# Altium schematic sheet Microcontroller.SchDoc(Microcontroller)
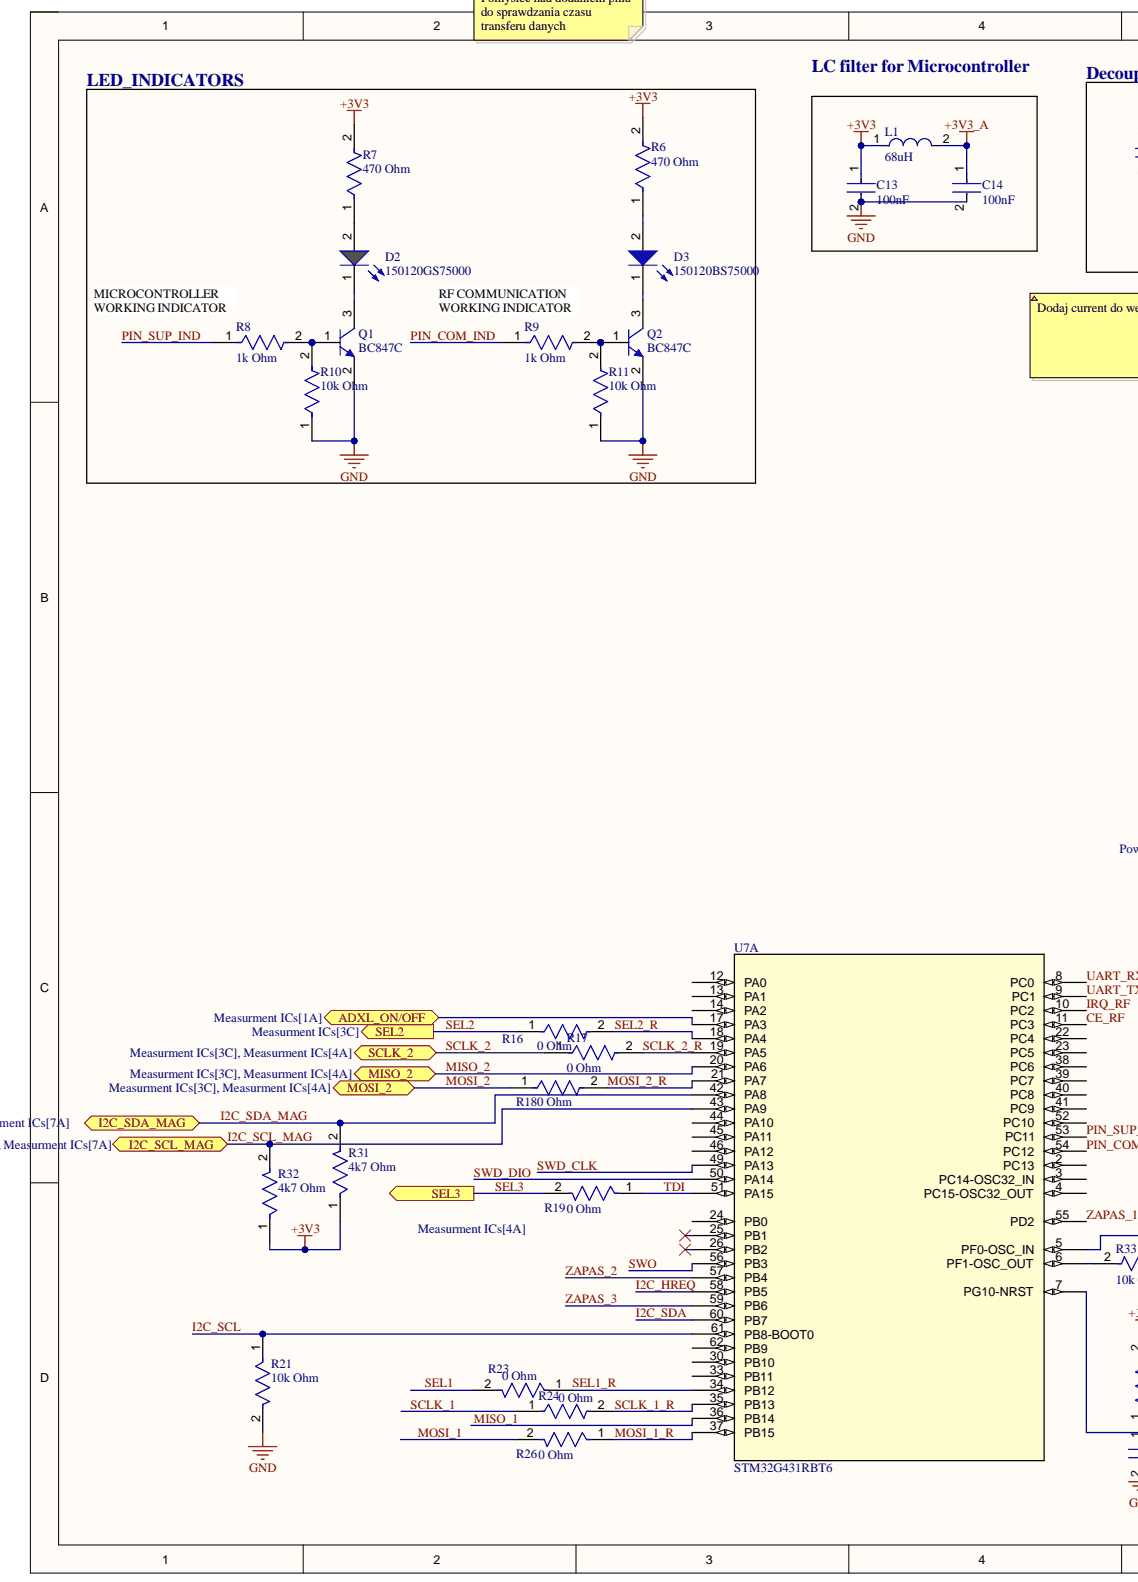
[diagram p0: Microcontroller.SchDoc, left]
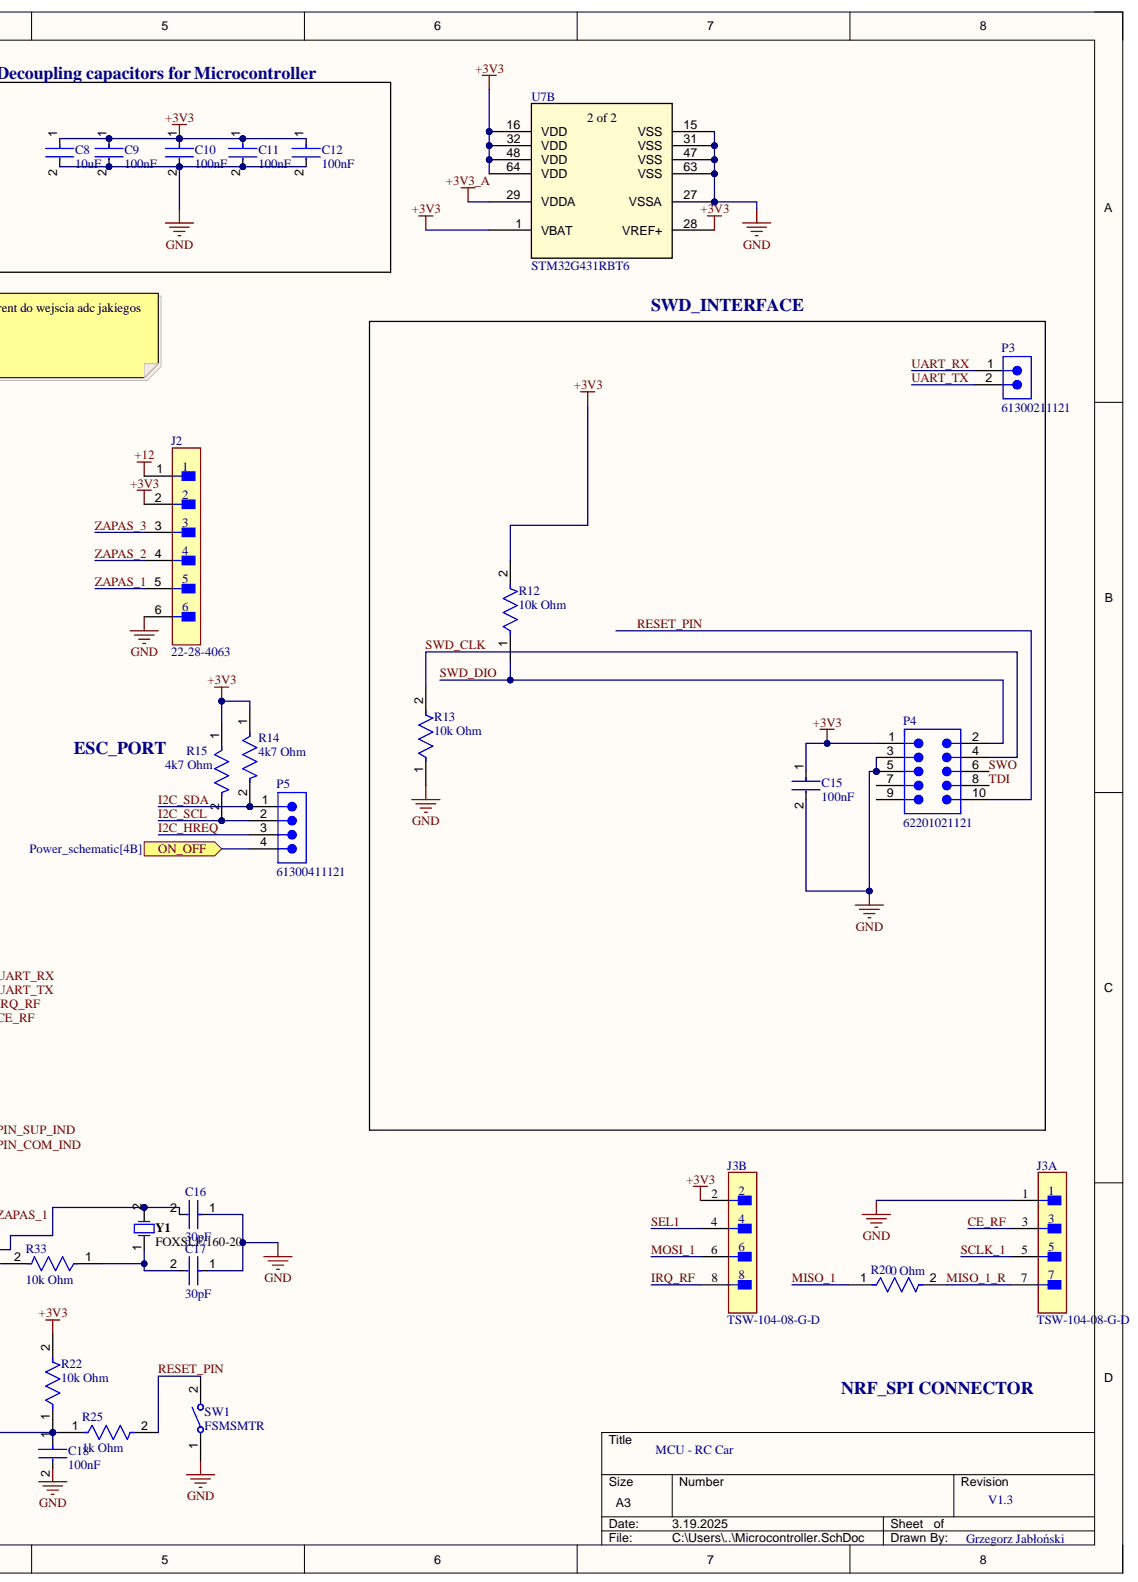
[diagram p1: Microcontroller.SchDoc, right]

## Parts
C8  10uF  [FP-CC0805-0_85-MFG]
C9  100nF  [FP-CC0805-0_85-MFG]
C10  100nF  [FP-CC0805-0_85-MFG]
C11  100nF  [FP-CC0805-0_85-MFG]
C12  100nF  [FP-CC0805-0_85-MFG]
C13  100nF  [FP-CC0805-0_85-MFG]
C14  100nF  [FP-CC0805-0_85-MFG]
C15  100nF  [FP-CC0805-0_85-MFG]
C16  30pF  [FP-CC0805-0_85-MFG]
C17  30pF  [FP-CC0805-0_85-MFG]
C18  100nF  [FP-CC0805-0_85-MFG]
D2  150120GS75000  [WL-SMCW_1206_150120xx75000]
D3  150120BS75000  [WL-SMCW_1206_150120xx75000]
J2  22-28-4063  [FP-22-28-4063-MFG]
J3  TSW-104-08-G-D  [FP-TSW-104-08-G-D-MFG]  (parts A,B)
L1  68uH  [FP-CB2518-MFG]
P3  61300211121  [61300211121]
P4  62201021121  [62201021121]
P5  61300411121  [61300411121]
Q1  BC847C  [INF-SOT23_L]
Q2  BC847C  [INF-SOT23_L]
R6  470 Ohm  [FP-RMCF0805-MFG]
R7  470 Ohm  [FP-RMCF0805-MFG]
R8  1k Ohm  [FP-RMCF0805-MFG]
R9  1k Ohm  [FP-RMCF0805-MFG]
R10  10k Ohm  [FP-RMCF0805-MFG]
R11  10k Ohm  [FP-RMCF0805-MFG]
R12  10k Ohm  [FP-RMCF0805-MFG]
R13  10k Ohm  [FP-RMCF0805-MFG]
R14  4k7 Ohm  [FP-RMCF0805-MFG]
R15  4k7 Ohm  [FP-RMCF0805-MFG]
R16  0 Ohm  [FP-RMCF0805-MFG]
R17  0 Ohm  [FP-RMCF0805-MFG]
R18  0 Ohm  [FP-RMCF0805-MFG]
R19  0 Ohm  [FP-RMCF0805-MFG]
R20  0 Ohm  [FP-RMCF0805-MFG]
R21  10k Ohm  [FP-RMCF0805-MFG]
R22  10k Ohm  [FP-RMCF0805-MFG]
R23  0 Ohm  [FP-RMCF0805-MFG]
R24  0 Ohm  [FP-RMCF0805-MFG]
R25  1k Ohm  [FP-RMCF0805-MFG]
R26  0 Ohm  [FP-RMCF0805-MFG]
R31  4k7 Ohm  [FP-RMCF0805-MFG]
R32  4k7 Ohm  [FP-RMCF0805-MFG]
R33  10k Ohm  [FP-RMCF0805-MFG]
SW1  FSMSMTR  [TECO-FSMSMTR_V]
U7  STM32G431RBT6  [LQFP64-10x10mm]  (parts A,B)
Y1  FOXSLF/160-20  [FOX-FOXSLF160-20]

## Ports
ADXL_ON/OFF, I2C_SCL_MAG, I2C_SDA_MAG, MISO_2, MOSI_2, ON_OFF, SCLK_2, SEL2, SEL3

## Nets
+3V3: C8.1 C9.1 C10.1 C11.1 C12.1 C13.1 C15.1 J2.2 J3.2 L1.1 P4.1 R6.2 R7.2 R12.2 R14.1 R15.1 R22.2 R31.1 R32.1 U7.1(VBAT) U7.16(VDD) U7.28(VREF+) U7.32(VDD) U7.48(VDD) U7.64(VDD)
+3V3_A: C14.1 L1.2 U7.29(VDDA)
+12: J2.1
GND: C8.2 C9.2 C10.2 C11.2 C12.2 C13.2 C14.2 C15.2 C16.1 C17.1 C18.2 J2.6 J3.1 P4.3 P4.5 Q1.2 Q2.2 R10.1 R11.1 R13.1 R21.2 SW1.1 U7.15(VSS) U7.27(VSSA) U7.31(VSS) U7.47(VSS) U7.63(VSS)
ADXL_ON/OFF: U7.17(PA3) ; port ADXL_ON/OFF
CE_RF: J3.3 U7.11(PC3)
I2C_HREQ: P5.3 U7.58(PB5)
I2C_SCL: P5.2 R15.2 R21.1 U7.61(PB8-BOOT0)
I2C_SCL_MAG: R32.2 U7.43(PA9) ; port I2C_SCL_MAG
I2C_SDA: P5.1 R14.2 U7.60(PB7)
I2C_SDA_MAG: R31.2 U7.42(PA8) ; port I2C_SDA_MAG
IRQ_RF: J3.8 U7.10(PC2)
MISO_1: R20.1 U7.36(PB14)
MISO_1_R: J3.7 R20.2
MISO_2: U7.20(PA6) ; port MISO_2
MOSI_1: J3.6 R26.2
MOSI_1_R: R26.1 U7.37(PB15)
MOSI_2: R18.1 ; port MOSI_2
MOSI_2_R: R18.2 U7.21(PA7)
ON_OFF: P5.4 ; port ON_OFF
PIN_COM_IND: R9.1 U7.54(PC12)
PIN_SUP_IND: R8.1 U7.53(PC11)
RESET_PIN: P4.10 R25.2 SW1.2
SCLK_1: J3.5 R24.1
SCLK_1_R: R24.2 U7.35(PB13)
SCLK_2: R17.1 ; port SCLK_2
SCLK_2_R: R17.2 U7.19(PA5)
SEL1: J3.4 R23.2
SEL1_R: R23.1 U7.34(PB12)
SEL2: R16.1 ; port SEL2
SEL2_R: R16.2 U7.18(PA4)
SEL3: R19.2 ; port SEL3
SWD_CLK: P4.4 R13.2 U7.49(PA13)
SWD_DIO: P4.2 R12.1 U7.50(PA14)
SWO: P4.6 U7.56(PB3)
TDI: P4.8 R19.1 U7.51(PA15)
UART_RX: P3.1 U7.8(PC0)
UART_TX: P3.2 U7.9(PC1)
ZAPAS_1: J2.5 U7.55(PD2)
ZAPAS_2: J2.4 U7.57(PB4)
ZAPAS_3: J2.3 U7.59(PB6)
NetC16_2: C16.2 U7.5(PF0-OSC_IN) Y1.2
NetC17_2: C17.2 R33.1 Y1.1
NetC18_1: C18.1 R22.1 R25.1 U7.7(PG10-NRST)
NetD2_1: D2.1 Q1.3
NetD2_2: D2.2 R7.1
NetD3_1: D3.1 Q2.3
NetD3_2: D3.2 R6.1
NetQ1_1: Q1.1 R8.2 R10.2
NetQ2_1: Q2.1 R9.2 R11.2
NetR33_2: R33.2 U7.6(PF1-OSC_OUT)

Not connected: P4 7 9; U7 2(PC13) 3(PC14-OSC32_IN) 4(PC15-OSC32_OUT) 12(PA0) 13(PA1) 14(PA2) 22(PC4) 23(PC5) 24(PB0) 25(PB1) 26(PB2) 30(PB10) 33(PB11) 38(PC6) 39(PC7) 40(PC8) 41(PC9) 44(PA10) 45(PA11) 46(PA12) 52(PC10) 62(PB9)

## Library data of the parts
C8 C16 C17 = CMP-2100-03606-3: CAP CER 0.1UF 50V X7R 0805; Manufacturer: Yageo; Manufacturer Part Number: CC0805KRX7R9BB104; Capacitance: 100 nF; Material: Ceramic; Tolerance: 0.1; Value: 100 nF; Automotive: No; Category: Cap; Component Kind: Standard; ComponentLink2Description: Datasheet; ComponentLink2URL: http://www.yageo.com/documents/recent/UPY-GPHC_Y5V_6.3V-to-50V_8.pdf; Device Class L1: Passive Components; Device Class L2: Capacitors; Device Class L3: Ceramic Capacitors; Digikey Description: CAP CER 0.1UF 50V X7R 0805; Footprint Url: http://www.yageo.com/exep/pages/download/literatures/UPY-C_GEN_24.pdf; Height: 0.95mm; Ipc Land Pattern Name: CAPC200125X85; Lead Free: Yes; Library Name: Altium Content Vault; Mouser Description: Multilayer Ceramic Capacitors MLCC - SMD/SMT 100nF 50V X7R 10%; Octopart: https://octopart.com/cc0805krx7r9bb104-yageo-192993; Package: CC0805-0.85; RoHS: TRUE; Temperature: -30°C to +85°C; Temperature Characteristic: X7R; Temperature Range High: +85°C; Temperature Range Low: -30°C; Voltage Rating: 50 V
C9-C15 C18 = CMP-2100-03606-3: CAP CER 0.1UF 50V X7R 0805; Manufacturer: Yageo; Manufacturer Part Number: CC0805KRX7R9BB104; Material: Ceramic; Tolerance: 0.1; Automotive: No; Capacitance: 100 nF; Category: Cap; Component Kind: Standard; ComponentLink2Description: Datasheet; ComponentLink2URL: http://www.yageo.com/documents/recent/UPY-GPHC_Y5V_6.3V-to-50V_8.pdf; Device Class L1: Passive Components; Device Class L2: Capacitors; Device Class L3: Ceramic Capacitors; Digikey Description: CAP CER 0.1UF 50V X7R 0805; Footprint Url: http://www.yageo.com/exep/pages/download/literatures/UPY-C_GEN_24.pdf; Height: 0.95mm; Ipc Land Pattern Name: CAPC200125X85; Lead Free: Yes; Library Name: Altium Content Vault; Mouser Description: Multilayer Ceramic Capacitors MLCC - SMD/SMT 100nF 50V X7R 10%; Octopart: https://octopart.com/cc0805krx7r9bb104-yageo-192993; Package: CC0805-0.85; RoHS: TRUE; Temperature: -30°C to +85°C; Temperature Characteristic: X7R; Temperature Range High: +85°C; Temperature Range Low: -30°C; Value: 100 nF; Voltage Rating: 50 V
D2 = CMP-1426-00014-4: WL-SMCW SMT Mono-Color Chip LED Waterclear; Color: Green; Dominant Wavelength: 525nm; Forward Current: 100mA; Forward Voltage: 3.2V; Lens Style: Clear, Rectangular; Luminous Intensity: 450mcd; Max Junction Temperature (Tj): 95°C; Max Reverse Voltage (DC): 5V; Peak Wavelength: 515nm; Power Dissipation: 105mW; Test Current: 20mA; Viewing Angle: 140°; Case Code (Imperial): 1206; Case Code (Metric): 3216; Case/Package: 1206; Component Kind: Standard; Height: 680µm; Length: 3.2mm; Library Name: Altium Content Vault; Max Operating Temperature: 85°C; Min Operating Temperature: -40°C; Mount: Surface Mount; Packaging: Tape and Reel; REACH SVHC: Unknown; Reverse Voltage: 5V; RoHS: Compliant; Wavelength: 525nm; Width: 1.6mm
D3 = CMP-1426-00015-3: WL-SMCW SMT Mono-Color Chip LED Waterclear; Manufacturer Package Identifier: LED_1206; Color: Blue; Dominant Wavelength: 470nm; Forward Current: 100mA; Forward Voltage: 3.2V; Lens Style: Clear, Rectangular; Luminous Intensity: 145mcd; Max Reverse Voltage (DC): 5V; Peak Wavelength: 465nm; Test Current: 20mA; Viewing Angle: 140°; Case Code (Imperial): 1206; Case Code (Metric): 3216; Case/Package: 1206; Component Kind: Standard; Height: 680µm; Length: 3.2mm; Library Name: Altium Content Vault; Max Operating Temperature: 85°C; Min Operating Temperature: -40°C; Mount: Surface Mount; Packaging: Tape and Reel; REACH SVHC: Unknown; RoHS: Compliant; Wavelength: 470nm; Width: 1.6mm
J2 = CMP-04776-000885-1: CONN HEADER VERT 6POS 2.54MM; Connector Type: Male Pin; Contact Resistance: 20mR; Current Rating: 4A; Gender: Male; Glow Wire Compliant: Non-compliant; Max Voltage Rating (AC): 250V; Max Voltage Rating (DC): 250.25kV; Number of Circuits: 6; Color: Black; Component Kind: Standard; Contact Material: Brass; Contact Mating Length: 0.24inch; Contact Plating: Gold; Contact Type: Male Pin; Depth: 2.49mm; Flammability Rating: UL94 V-0; Housing Color: Black; Housing Material: Thermoplastic; Lead Free: Lead Free; Library Name: Altium Content Vault; Max Operating Temperature: 75°C; Min Operating Temperature: 0°C; Mount: Through Hole; Number of Contacts: 6; Number of Positions: 6; Number of Rows: 1; Orientation: Straight; Package Quantity: 1; Packaging: Bulk; Pitch: 2.54mm; Radiation Hardening: No; RoHS Compliant: Yes; Series: KK® 42375; Termination: Solder; Voltage Rating: 250V; Voltage Rating (DC): 250V
J3 = CMP-00575-00272549-1: TSW-104-08-G-D TSW - Samtec 2.54mm Pin Header 13.46mm Overall Pin Length 5.08mm Tail (08) (mcv)(TO22); Manufacturer Lifecycle Status: PRODUCTION; NexarPartId: 272549; Connector Type: Male Pin; Gender: Male; Max Current Rating: 7.6A; Max Voltage Rating (AC): 550V; Number of Contacts: 8; Color: Black; Component Kind: Standard; Contact Material: Bronze; Contact Mating Length: 5.842mm; Contact Plating: Gold; Depth: 5.08mm; Housing Color: Black; Housing Material: Polyester; Lead Free: Lead Free; Lead Pitch: 2.54mm; Length: 10.16mm; Library Name: Altium Content Vault; Lifecycle Status: Production; Max Operating Temperature: 125°C; Min Operating Temperature: -55°C; Mount: Through Hole; Number of Positions: 8; Number of Rows: 2; Orientation: Straight; Packaging: Bulk; Pitch: 2.54mm; Radiation Hardening: No; REACH SVHC: No SVHC; RoHS: Compliant; Row Spacing: 2.54mm; Termination: Solder; Width: 5.08mm
L1 = CMP-14484-000100-1: SMD Inductors 68uH ±10% 0.31A 1.95Ω 1007; Core Material: Ferrite; Current Rating: 310mA; DC Resistance (DCR): 1.95R; Saturation Current: 70mA; Self Resonant Frequency: 9.5MHz; Shielding: Unshielded; Test Frequency: 2.52MHz; Case Code (Imperial): 1007; Case Code (Metric): 2518; Case/Package: 1007; Component Kind: Standard; Depth: 2mm; Halogen Free: Halogen Free; Height: 1.8mm; Height - Seated (Max): 0.079inch; Inductance: 68uH; Inductance Tolerance: 10%; Length: 0.098inch; Library Name: Altium Content Vault; Max DC Current: 310mA; Max Operating Temperature: 105°C; Min Operating Temperature: -40°C; Mount: Surface Mount; Package Quantity: 2000; Packaging: Tape and Reel; Radiation Hardening: No; Resistor Type: Wirewound; RoHS Compliant: Yes; Series: CB; Termination: SMD/SMT; Tolerance: 10%; Width: 0.071inch
P3 = CMP-1712-00002-6: NexarPartId: 20081794; Connector Type: Male Pin; Contact Resistance: 20mΩ; Current Rating: 3A; Gender: Male; Number of Contacts: 2; Operating Supply Voltage: 250V; Pitch: 2.54mm; Color: Black; Component Kind: Standard; Contact Gender: Male; Contact Material: Copper; Contact Mating Length: 5.9944mm; Contact Plating: Gold; Height: 8.94mm; Housing Material: PBT; Lead Free: Lead Free; Lead Pitch: 2.54mm; Library Name: Altium Content Vault; Max Operating Temperature: 125°C; Min Operating Temperature: -40°C; Mount: Through Hole; Number of Pins: 2; Number of Positions: 2; Number of Rows: 1; Orientation: Vertical; Packaging: Bulk; REACH SVHC: No SVHC; RoHS: Compliant; Termination: Through Hole; Voltage Rating: 250V
P4 = CMP-1502-00948-3: NexarPartId: 32855586; Connector Type: Male Pin; Contact Resistance: 20mΩ; Current Rating: 1A; Gender: Male; Number of Contacts: 10; Operating Supply Voltage: 100V; Pitch: 1.27mm; Color: Black; Component Kind: Standard; Contact Material: Copper; Contact Mating Length: 3.81mm; Contact Plating: Gold; Height: 5.65mm; Lead Pitch: 1.27mm; Library Name: Altium Content Vault; Max Operating Temperature: 105°C; Min Operating Temperature: -40°C; Mount: Through Hole; Number of Pins: 10; Number of Positions: 10; Number of Rows: 2; Orientation: Vertical; Packaging: Box; REACH SVHC: Unknown; RoHS: Compliant; Row Spacing: 1.27mm; Termination: Solder; Voltage Rating: 100V
P5 = CMP-1502-01064-3: NexarPartId: 32855522; Connector Type: Male Pin; Contact Resistance: 20mΩ; Current Rating: 3A; Gender: Male; Number of Contacts: 4; Operating Supply Voltage: 250V; Pitch: 2.54mm; Color: Black; Component Kind: Standard; Contact Material: Copper; Contact Mating Length: 5.9944mm; Contact Plating: Gold; Height: 8.89mm; Lead Free: Lead Free; Lead Pitch: 2.54mm; Library Name: Altium Content Vault; Max Operating Temperature: 105°C; Min Operating Temperature: -40°C; Mount: Through Hole; Number of Pins: 4; Number of Positions: 4; Number of Rows: 1; Orientation: Vertical; Packaging: Bulk; REACH SVHC: Unknown; RoHS: Compliant; Termination: Solder; Voltage Rating: 250V
Q1 Q2 = CMP-1177-00037-1: NPN Silicon AF Transistor, 45 V VCEO, 100 mA IC, SOT23, Reel, Green; Manufacturer: Infineon; fT (typ): 250Â MHz; Green: TRUE; IBM (max): 200Â mA; IC (max): 100Â mA; ICBO (max): 15Â nA; Ptot (max): 330Â mW; VCBO (max): 50Â V; VCEO (max): 45Â V; VEBO (max): 6Â V; Component Kind: Standard; ComponentLink1Description: Manufacturer URL; ComponentLink1URL: http://www.infineon.com/; ComponentLink2Description: Datasheet; ComponentLink2URL: http://www.infineon.com/dgdl/bc847series_bc848series_bc849series_bc850series.pdf?folderId=db3a304314dca389011541d30fa21656&fileId=db3a304314dca389011541d4630a1657; ComponentLink3Description: Package Specification; ComponentLink3URL: http://www.infineon.com/dgdl/SOT23-Package_Overview.pdf?folderId=db3a30431936bc4b0119532290053c66&fileId=db3a30431936bc4b0119533468123c85; ICM (max): 200Â mA; Library Name: Altium Content Vault; Mounting Technology: Surface Mount; PackageDescription: 3-Pin Small Outline Transistor Package, 1.3 x 2.9 mm Body, 0.95 mm Pitch; PackageReference: SOT23; PackageVersion: Apr-08; Packing: Reel; PartNumber: BC847C; Polarity: NPN
R6-R26 R31-R33 = CMP-26527-000017-1: RES 0 OHM JUMPER 1/8W 0805; Max Power Dissipation: 125mW; Resistance: 0mR; Case Code (Imperial): 0805; Case Code (Metric): 2012; Case/Package: 0805; Component Kind: Standard; Composition: Thick Film; Contact Plating: Tin; Depth: 1.25mm; Features: Automotive AEC-Q200; Height: 0.026inch; Lead Free: Lead Free; Length: 2mm; Library Name: Altium Content Vault; Max Operating Temperature: 155°C; Military Standard: Not; Min Operating Temperature: -55°C; Mount: Surface Mount; Number of Terminations: 2; Packaging: Tape and Reel; Power Rating: 125mW; Radiation Hardening: No; Width: 0.049inch
SW1 = CMP-2000-07501-1: FSMSM Push Button Switch, 50 mA, -35 to 85 degC, 2-Pin SMD, RoHS, Tape and Reel; Manufacturer: TE Connectivity; Actuator Length: 1.07mm; Actuator Material: Polyamide; Case\/Package: SMD/SMT; Contact Current Rating: 50mA; Contact Resistance: 100mOhm; Electrical Life: 20000Cycles; Illumination Type: Illuminated; Max Voltage Rating (DC): 12V; Operating Force: 180gf; Switch Travel: 0.25mm; Throw Configuration: SPST; Voltage Rating (DC): 24V; Component Kind: Standard; Contact Material: Brass; Contact Plating: Silver; Current Rating: 50mA; Datasheet URL: http://www.te.com/commerce/DocumentDelivery/DDEController?Action=showdoc&DocId=Customer+Drawing%7F1437566-3%7FC2%7Fpdf%7FEnglish%7FENG_CD_1437566-3_C2_1437566_3_1_c.dwg.pdf%7F1437566-4; Datasheet Version: Rev. C2, 08/2014; ELV: Compliant; Lead Free: LeadFree; Library Name: Altium Content Vault; Manufacturer URL: http://www.te.com/; Max Operating Temperature: 85degC; Mechanical Life: 20000Cycles; Min Operating Temperature: -35degC; Mount: SurfaceMount; Mounting Technology: SurfaceMount; Orientation: Straight; Package Description: 2-Pin Surface Mount Device, Body 6 x 3.5 mm, Pitch 9.19 mm; Package Quantity: 1800; Package Reference: FSMSMTR; Packaging: TapeandReel; REACH SVHC: Unknown; RoHSCompliant: true; Termination: GullWing
U7 = STM32G431RBT6: No Description Available; Mfr_Name: STMicroelectronics; Component Kind: Standard; Library Name: STM32G431RBT6.IntLib; RefDes: RefDes; Type: Type
Y1 = CMP-1749-00003-1: Resistance Weld Thru-Hole Crystal, 16 MHz, -20 to 70 degC, 2-Pin THD, RoHS, Bulk; Case\Package: Axial; Power Rating: 250 mW; Resistance: 221 kohm; Tolerance: 1%; Voltage Rating: 250 V; Component Kind: Standard; ComponentLink1Description: Manufacturer URL; ComponentLink1URL: http://www.foxonline.com/; ComponentLink2Description: Datasheet; ComponentLink2URL: http://www.foxonline.com/pdfs/hc49slf.pdf; DatasheetVersion: Mar-15; Length: 0.248 inch; Library Name: Altium Content Vault; Max Operating Temperature: 155 degC; Min Operating Temperature: -55 degC; Mount: Through Hole; Package Quantity: 200; PackageDescription: 2-Pin Through Hole Device, Body 11.35 x 4.65 mm; PackageReference: FOXSLF160-20; Packaging: Bulk; RoHSCompliant: true
